# Altium schematic sheet discrete_parts.SchDoc
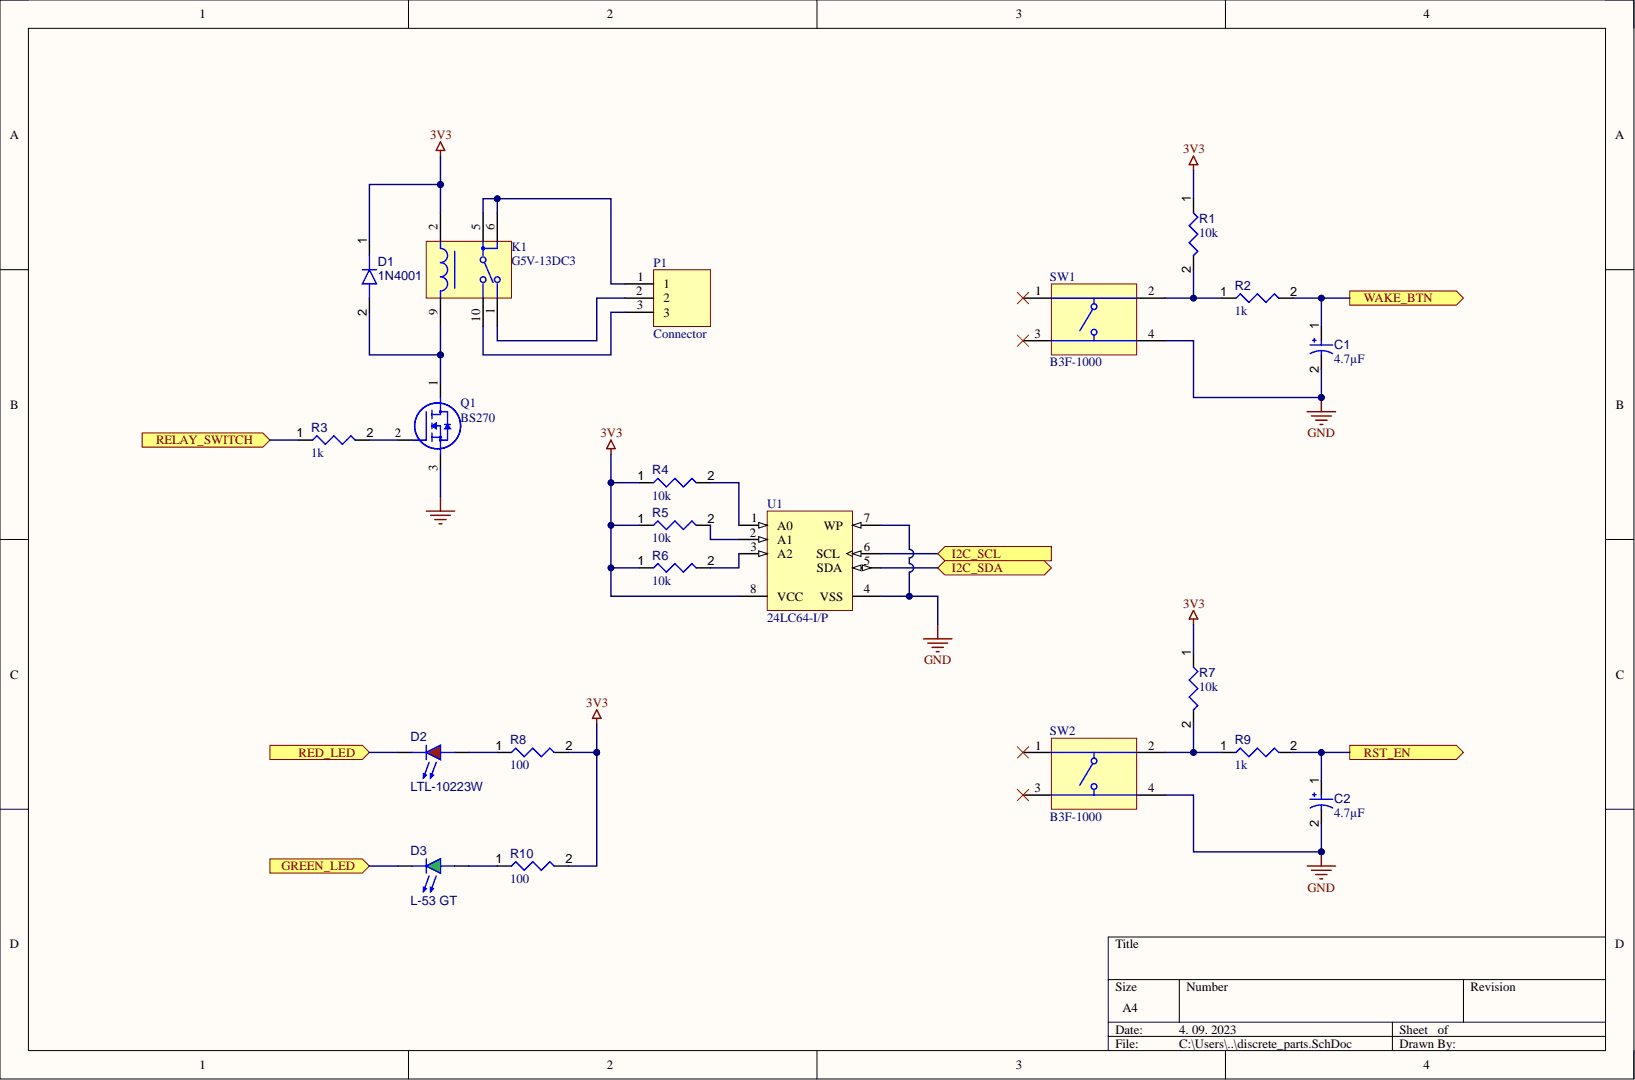
[diagram p0: discrete_parts.SchDoc]

## Parts
C1  value 4.7µF  [CAPPR55-200-1100X500X1200]  (hidden comment: ECA1HM4R7)
C2  value 4.7µF  [CAPPR55-200-1100X500X1200]  (hidden comment: ECA1HM4R7)
D1  1N4001  [Diode]
D2  LTL-10223W  [LED_RED]
D3  L-53 GT  [LED_GREEN]
K1  G5V-13DC3  [FP-G5V-13_DC3-MFG]
P1  Connector  [FP_CONN3_TUSKE_254MM]
Q1  BS270  [BS270-Footprint-1]
R1  value 10k  [RESA60-630X240]  (hidden comment: MFR-25FBF52-10K)
R2  value 1k  [RESA60-630X240]  (hidden comment: MFR-25FBF52-10K)
R3  value 1k  [RESA60-630X240]  (hidden comment: MFR-25FBF52-10K)
R4  value 10k  [RESA60-630X240]  (hidden comment: MFR-25FBF52-10K)
R5  value 10k  [RESA60-630X240]  (hidden comment: MFR-25FBF52-10K)
R6  value 10k  [RESA60-630X240]  (hidden comment: MFR-25FBF52-10K)
R7  value 10k  [RESA60-630X240]  (hidden comment: MFR-25FBF52-10K)
R8  value 100  [RESA60-630X240]  (hidden comment: MFR-25FBF52-10K)
R9  value 1k  [RESA60-630X240]  (hidden comment: MFR-25FBF52-10K)
R10  value 100  [RESA60-630X240]  (hidden comment: MFR-25FBF52-10K)
SW1  B3F-1000  [OMRON-B3F-1000-4_V]
SW2  B3F-1000  [OMRON-B3F-1000-4_V]
U1  24LC64-I/P  [PDIP300-P8]

## Ports
GREEN_LED, I2C_SCL, I2C_SDA, RED_LED, RELAY_SWITCH, RST_EN, WAKE_BTN

## Nets
3V3: D1.1 K1.2 R1.1 R4.1 R5.1 R6.1 R7.1 R8.2 R10.2 U1.8(VCC)
GND: C1.2 C2.2 Q1.3 SW1.4 SW2.4 U1.4(VSS) U1.7(WP)
GREEN_LED: D3.1 ; port GREEN_LED
I2C_SCL: U1.6(SCL) ; port I2C_SCL
I2C_SDA: U1.5(SDA) ; port I2C_SDA
RED_LED: D2.1 ; port RED_LED
RELAY_SWITCH: R3.1 ; port RELAY_SWITCH
RST_EN: C2.1 R9.2 ; port RST_EN
WAKE_BTN: C1.1 R2.2 ; port WAKE_BTN
NetD1_2: D1.2 K1.9 Q1.1
NetD2_2: D2.2 R8.1
NetD3_2: D3.2 R10.1
NetK1_1: K1.1 P1.2
NetK1_5: K1.5 K1.6 P1.1
NetK1_10: K1.10 P1.3
NetQ1_2: Q1.2 R3.2
NetR1_2: R1.2 R2.1 SW1.2
NetR4_2: R4.2 U1.1(A0)
NetR5_2: R5.2 U1.2(A1)
NetR6_2: R6.2 U1.3(A2)
NetR7_2: R7.2 R9.1 SW2.2

Not connected: SW1 1 3; SW2 1 3

## Library data of the parts
C1 C2 = CMP-001-00033-7: Aluminum Electrolytic Capacitor, 4.7 uF, +/- 20%, 50 V, -40 to 85 degC, 2-Pin THD, RoHS, Bulk; Manufacturer: Panasonic; Ripple Current: 45mA; Voltage Rating: 50V; Capacitor Type: Electrolytic; Case/Package: Radial; Component Kind: Standard; Datasheet URL: https://industrial.panasonic.com/cdbs/www-data/pdf/RDF0000/ABA0000C1218.pdf; Datasheet Version: Rev. 05, 10/2016; Diameter: 5mm; Lead Pitch: 2mm; Manufacturer URL: http://www.panasonic.com/; Max Operating Temperature: 85°C; Min Operating Temperature: -40°C; Mounting Technology: TH; Package Description: 2-Pin Radial Lead, Dia 5 mm, Height 12 mm; Package Quantity: 200; Package Reference: CAPPR55-200-1100X500X1200; Packaging: Bulk; Pins: 2; Ripple Current (AC): 45mA; RoHS Compliant: True; Tolerance: 20%; Library Name: Budapest University of Technology and Economics
D1 = CMP-004-00035-1: Rectifier Diode, 1 Element, 1A, 50V V(RRM), Silicon, DO-41; Case/Package: DO-41; Component Kind: Standard; Max Operating Temperature: 175°C; Min Operating Temperature: -50°C; Mounting Technology: Through Hole; Pins: 2; RoHS Compliant: Yes; Library Name: Budapest University of Technology and Economics
D2 = CMP-007-00037-1: Single Color LED, High Efficiency Red, Red Diffused, T-1 3/4, 4.75mm; Forward Current: 30mA; Forward Voltage: 2.8V; Colour: Red; Component Kind: Standard; Max Operating Temperature: 100°C; Min Operating Temperature: -55°C; Mounting Technology: Radial; Pins: 2; RoHS Compliant: Yes; Library Name: Budapest University of Technology and Economics
D3 = CMP-007-00036-1: Green T-1 3/4 5 mm 60° Tinted Diffused 600 mcd 1.85 V LED Lamp Through Hole; Forward Current: 10mA; Forward Voltage: 2.2V; Colour: Green; Component Kind: Standard; Mounting Technology: Through Hole; Pins: 2; Library Name: Budapest University of Technology and Economics
K1 = CMP-008-00006-3: RELAY GEN PURPOSE SPDT 1A 3VDC; Component Kind: Standard; Library Name: Budapest University of Technology and Economics
P1 = Connector_3pin: 3pin connector; Component Kind: Standard; Library Name: hallglib.SchLib
Q1 = CMP-013-00041-2: N-Channel MOSFET, Enhancement Mode, 60V, 400mA, 2Ω; Nominal Vgs: 2.1V; Case/Package: TO-92; Component Kind: Standard; Max Operating Temperature: 150°C; Min Operating Temperature: -55°C; Mounting Technology: Through Hole; Pins: 3; RoHS Compliant: Yes; Library Name: Budapest University of Technology and Economics
R1-R10 = CMP-009-00047-4: Axial Resistor, 10 KOhm, +/- 1%, 0.25 W, -55 to 155 degC, 2-Pin THD, RoHS, Bulk; Manufacturer: Yageo; Power: 250mW; Voltage Rating: 250V; Case/Package: Axial; Component Kind: Standard; Datasheet URL: http://www.yageo.com/documents/recent/Yageo%20LR_MFR_2013.pdf; Datasheet Version: Apr-13; Manufacturer URL: http://www.yageo.com/; Max Operating Temperature: 155°C; Min Operating Temperature: -55°C; Mounting Technology: TH; Package Description: 2-Pin Axial Lead, Dia 2.4 mm, Body Length 6.3 mm; Package Quantity: 200; Package Reference: MFR-25; Packaging: Bulk; RoHS Compliant: True; Tolerance: 1%; Library Name: Budapest University of Technology and Economics
SW1 SW2 = CMP-011-00004-2: Tactile Switch, SPST-NO, 0.05 A, 24 V, -25 to 70 degC, 4-Pin THD, RoHS, Bulk; Manufacturer: Omron Electronic Components; Contact Current Rating: 50mA; Max Contact Resistance: 100mohm; Max Voltage Rating (DC): 24V; Operating Force: 100gf; Resistance: 0.1Ohm; Throw Configuration: SPST; Case/Package: B3F-1000; Component Kind: Standard; Contact Material: Gold; Contact Plating: Gold; Current Rating: 50mA; Datasheet URL: http://www.omron.com/ecb/products/pdf/en-b3f.pdf; Datasheet Version: Jan-15; Manufacturer URL: http://www.omron.com/; Max Current Rating: 50mA; Max Operating Temperature: 70°C; Min Operating Temperature: -25°C; Mounting Technology: TH; Package Description: 4-Pin Through Hole Device, Body 6 x 6 mm; Package Quantity: 1500; Package Reference: B3F-1000; Packaging: Bulk; Pins: 4; RoHS Compliant: True; Voltage Rating: 24V; Voltage Rating (DC): 24V; Library Name: Budapest University of Technology and Economics
U1 = CMP-019-00051-1: EEPROM Serial-I2C 64K-bit 8K x 8 3.3V/5V 8-Pin PDIP Tube; Clock Frequency: 400kHz; Max Supply Voltage: 5.5V; Memory Size: 64Kb; Min Supply Voltage: 2.5V; Case/Package: PDIP; Component Kind: Standard; Frequency: 400kHz; Max Operating Temperature: 85°C; Memory Format: EEPROM; Min Operating Temperature: -40°C; Mounting Technology: Through Hole; Pins: 8; RoHS Compliant: Yes; Library Name: Budapest University of Technology and Economics
